# Altium schematic sheet iocodec.SchDoc(iocodec)
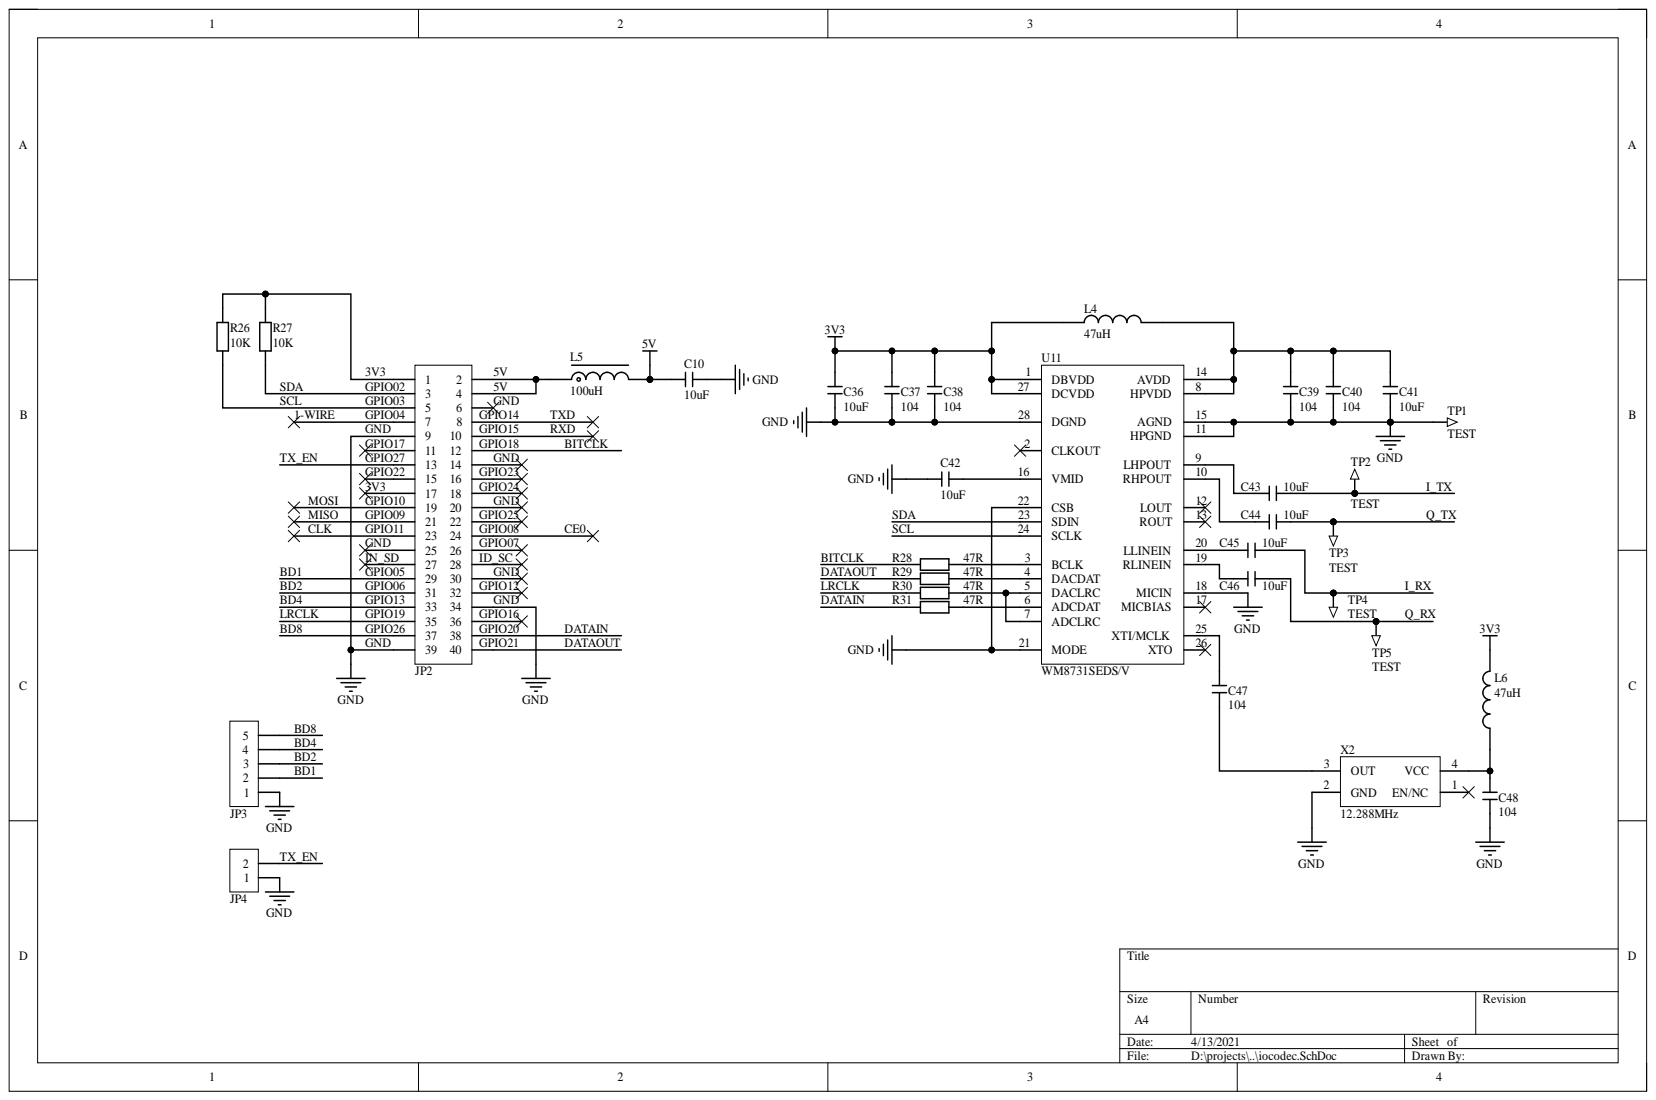
[diagram p0: iocodec.SchDoc]

## Parts
C10  10uF  [C 0603_L]
C36  10uF  [C 0603_L]
C37  104  [C 0603_L]
C38  104  [C 0603_L]
C39  104  [C 0603_L]
C40  104  [C 0603_L]
C41  10uF  [C 0603_L]
C42  10uF  [C 0603_L]
C43  10uF  [C 0603_L]
C44  10uF  [C 0603_L]
C45  10uF  [C 0603_L]
C46  10uF  [C 0603_L]
C47  104  [C 0603_L]
C48  104  [C 0603_L]
JP2  Header 2X11  [HDR2.54-LI-2x20P]  (value not drawn)
JP3  HDR-1X5  [HDR2.54-LI-5P]  (value not drawn)
JP4  HDR-1X2  [HDR2.54-LI-2P]  (value not drawn)
L4  47uH  [L 0603]
L5  100uH  [CD31]
L6  47uH  [L 0603]
R26  10K  [R 0603_L]
R27  10K  [R 0603_L]
R28  47R  [R 0603_L]
R29  47R  [R 0603_L]
R30  47R  [R 0603_L]
R31  47R  [R 0603_L]
TP1  TEST  [TEST 1.6]
TP2  TEST  [TEST 1.6]
TP3  TEST  [TEST 1.6]
TP4  TEST  [TEST 1.6]
TP5  TEST  [TEST 1.6]
U11  WM8731SEDS/V  [SSOP28_L]
X2  12.288MHz  [OSC 3225-4P]

## Nets
3V3: C36.1 C37.1 C38.1 L4.1 L6.2 U11.1(DBVDD) U11.27(DCVDD)
5V: C10.1 L5.2
GND: C10.2 C36.2 C37.2 C38.2 C39.2 C40.2 C41.2 C42.1 C48.1 JP2.9 JP2.34 JP2.39 JP3.1 JP4.1 TP1.1 U11.11(HPGND) U11.15(AGND) U11.18(MICIN) U11.21(MODE) U11.22(CSB) U11.28(DGND) X2.2(GND)
BD1: JP2.29 JP3.2
BD2: JP2.31 JP3.3
BD4: JP2.33 JP3.4
BD8: JP2.37 JP3.5
BITCLK: JP2.12 R28.1
DATAIN: JP2.38 R31.1
DATAOUT: JP2.40 R29.1
I_RX: C45.1 TP4.1
I_TX: C43.1 TP2.1
LRCLK: JP2.35 R30.1
Q_RX: C46.1 TP5.1
Q_TX: C44.1 TP3.1
SCL: JP2.5 R26.1 U11.24(SCLK)
SDA: JP2.3 R27.1 U11.23(SDIN)
TX_EN: JP2.13 JP4.2
NetC39_1: C39.1 C40.1 C41.1 L4.2 U11.8(HPVDD) U11.14(AVDD)
NetC42_2: C42.2 U11.16(VMID)
NetC43_2: C43.2 U11.9(LHPOUT)
NetC44_2: C44.2 U11.10(RHPOUT)
NetC45_2: C45.2 U11.20(LLINEIN)
NetC46_2: C46.2 U11.19(RLINEIN)
NetC47_1: C47.1 U11.25(XTI/MCLK)
NetC47_2: C47.2 X2.3(OUT)
NetC48_2: C48.2 L6.1 X2.4(VCC)
NetJP2_1: JP2.1 R26.2 R27.2
NetJP2_2: JP2.2 JP2.4 L5.1
NetR28_2: R28.2 U11.3(BCLK)
NetR29_2: R29.2 U11.4(DACDAT)
NetR30_2: R30.2 U11.5(DACLRC) U11.7(ADCLRC)
NetR31_2: R31.2 U11.6(ADCDAT)

Not connected: JP2 6 7 8 10 11 14 15 16 17 18 19 20 21 22 23 24 25 26 27 28 30 32 36; U11 2(CLKOUT) 12(LOUT) 13(ROUT) 17(MICBIAS) 26(XTO); X2 1(EN/NC)

## Library data of the parts
C10 C36-C48 = C: 无极性贴片电容; 版权归属: 源创客; BOM名称: 无极性贴片电容; Component Kind: Standard; Library Name: 3.1   - 电阻-电容-电感.SchLib; 学习资源: www.ooocke.com
JP2 = Header 20X2: 20*2P接插件; 版权归属: 源创客; BOM名称: 20*2P接插件; Component Kind: Standard; Library Name: 4.5   - 接插件-脚距2.54.SchLib; 学习资源: www.ooocke.com
JP3 = Header 5: 5P接插件; 版权归属: 源创客; BOM名称: 5P接插件; Component Kind: Standard; Library Name: 4.5   - 接插件-脚距2.54.SchLib; 学习资源: www.ooocke.com
JP4 = Header 2: 2P接插件; 版权归属: 源创客; BOM名称: 2P接插件; Component Kind: Standard; Library Name: 4.5   - 接插件-脚距2.54.SchLib; 学习资源: www.ooocke.com
L4 L6 = L: 小功率贴片电感; 版权归属: 源创客; BOM名称: 小功率贴片电感; Component Kind: Standard; Library Name: 3.1   - 电阻-电容-电感.SchLib; 学习资源: www.ooocke.com
L5 = L-CD: 贴片功率电感; BOM名称: 功率电感; 版权归属: 源创客; Component Kind: Standard; Library Name: 3.1   - 电阻-电容-电感.SchLib; 学习资源: www.ooocke.com
R26-R31 = R: 贴片电阻; 版权归属: 源创客; BOM名称: 贴片电阻; Component Kind: Standard; Library Name: 3.1   - 电阻-电容-电感.SchLib; 学习资源: www.ooocke.com
TP1-TP5 = TEST: 测试点; 版权归属: 源创客; BOM名称: 测试点; Component Kind: Standard; Library Name: 15.1 - 未分类器件.SchLib; 学习资源: www.ooocke.com
U11 = WM8731SEDS/V: Portable Internet Audio CODEC with Headphone Driver and Programmable Sample Rates; Component Kind: Standard; Library Name: Schlib.SchLib
X2 = XTAL-ACT-4P: 4脚有源晶振; 版权归属: 源创客; BOM名称: 4脚有源晶振; Component Kind: Standard; Library Name: 10.1 - 保险丝-晶振.SchLib; 学习资源: www.ooocke.com
